# Altium schematic sheet Ribbon Breakout.SchDoc("Ribbon Breakout")
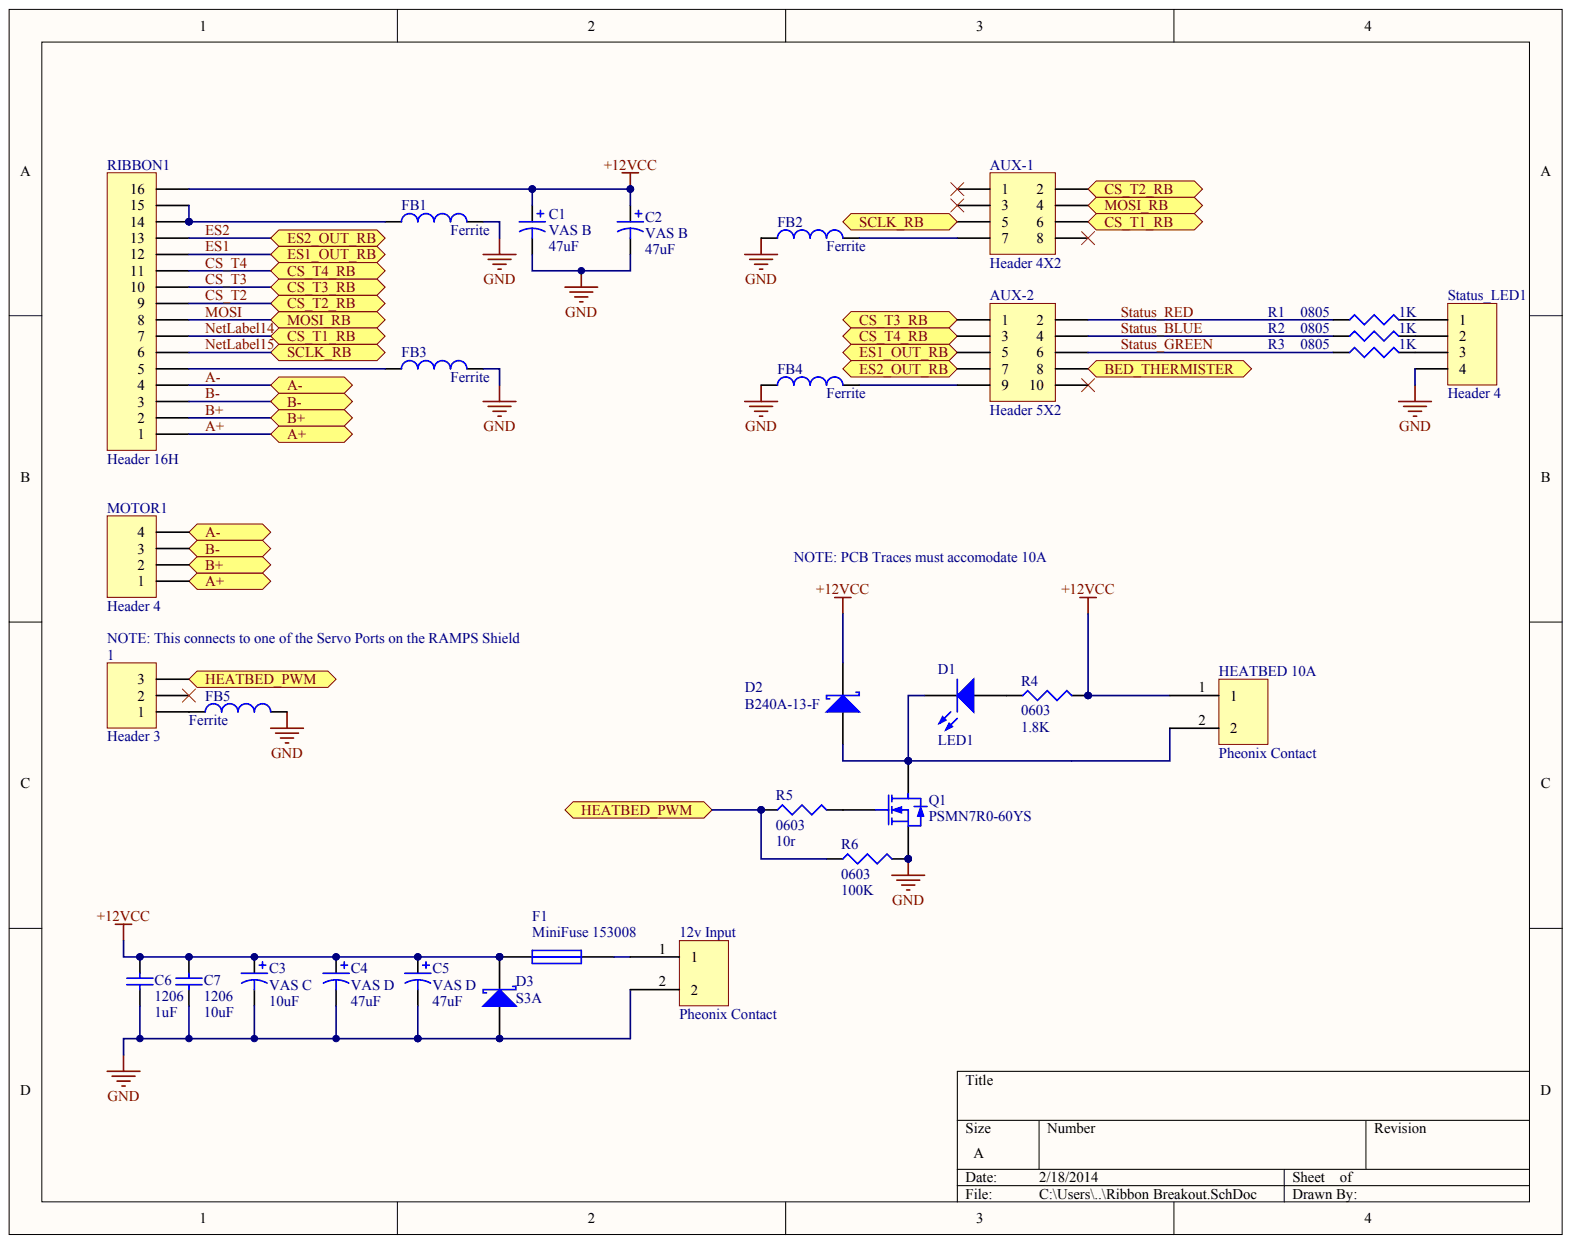
[diagram p0: Ribbon Breakout.SchDoc]

## Parts
1  Header 3
12v_Input  Pheonix Contact
AUX-1  Header 4X2
AUX-2  Header 5X2
C1  VAS B  value 47uF
C2  VAS B  value 47uF
C3  VAS C  value 10uF
C4  VAS D  value 47uF
C5  VAS D  value 47uF
C6  1206  value 1uF
C7  1206  value 10uF
D1  LED1
D2  B240A-13-F
D3  S3A
F1  MiniFuse 153008
FB1  Ferrite
FB2  Ferrite
FB3  Ferrite
FB4  Ferrite
FB5  Ferrite
HEATBED_10A  Pheonix Contact
MOTOR1  Header 4
Q1  PSMN7R0-60YS
R1  0805  value 1K
R2  0805  value 1K
R3  0805  value 1K
R4  0603  value 1.8K
R5  0603  value 10r
R6  0603  value 100K
RIBBON1  Header 16H
Status_LED1  Header 4

## Ports
A+, A-, B+, B-, BED_THERMISTER, CS_T1_RB, CS_T2_RB, CS_T3_RB, CS_T4_RB, ES1_OUT_RB, ES2_OUT_RB, HEATBED_PWM, MOSI_RB, SCLK_RB

## Nets
+12VCC: C1.1 C2.1 C3.1 C4.1 C5.1 C6.2 C7.2 D2.1 D3.2 F1.1 HEATBED_10A.1 R4.2 RIBBON1.16
GND: 12v_Input.2 C1.2 C2.2 C3.2 C4.2 C5.2 C6.1 C7.1 D3.1 FB1.2 FB2.1 FB3.2 FB4.1 FB5.2 Q1.1 Q1.2 Q1.3 R6.2 Status_LED1.4
A+: MOTOR1.1 RIBBON1.1 ; port A+
A-: MOTOR1.4 RIBBON1.4 ; port A-
B+: MOTOR1.2 RIBBON1.2 ; port B+
B-: MOTOR1.3 RIBBON1.3 ; port B-
BED_THERMISTER: AUX-2.8 ; port BED_THERMISTER
CS_T2: AUX-1.2 RIBBON1.9 ; port CS_T2_RB
CS_T3: AUX-2.1 RIBBON1.10 ; port CS_T3_RB
CS_T4: AUX-2.3 RIBBON1.11 ; port CS_T4_RB
ES1: AUX-2.5 RIBBON1.12 ; port ES1_OUT_RB
ES2: AUX-2.7 RIBBON1.13 ; port ES2_OUT_RB
HEATBED_PWM: 1.3 R5.1 R6.1 ; port HEATBED_PWM
MOSI: AUX-1.4 RIBBON1.8 ; port MOSI_RB
NETLABEL14: AUX-1.6 RIBBON1.7 ; port CS_T1_RB
NETLABEL15: AUX-1.5 RIBBON1.6 ; port SCLK_RB
STATUS_BLUE: AUX-2.4 R2.1
STATUS_GREEN: AUX-2.6 R3.1
STATUS_RED: AUX-2.2 R1.1
Net1_1: 1.1 FB5.1
Net12v Input_1: 12v_Input.1 F1.2
NetAUX-1_7: AUX-1.7 FB2.2
NetAUX-2_9: AUX-2.9 FB4.2
NetD1_1: D1.1 D2.2 HEATBED_10A.2 Q1.5
NetD1_2: D1.2 R4.1
NetFB1_1: FB1.1 RIBBON1.14 RIBBON1.15
NetFB3_1: FB3.1 RIBBON1.5
NetQ1_4: Q1.4 R5.2
NetR1_2: R1.2 Status_LED1.1
NetR2_2: R2.2 Status_LED1.2
NetR3_2: R3.2 Status_LED1.3

Not connected: 1 2; AUX-1 1 3 8; AUX-2 10

## Library data of the parts
FB1-FB5 = (no symbol name): Code_IEC: 1005; Published: 8-Jun-2000; LatestRevisionDate: 17-Jul-2002; LatestRevisionNote: Re-released for DXP Platform.; PackageReference: 0402-A; Code_JEITA: 0402; Publisher: Altium Limited
R4 = (no symbol name): Value: 1.8K; Published: 8-Jun-2000; LatestRevisionDate: 29-May-2009; LatestRevisionNote: IPC-7351 Footprint Added.; PackageReference: J1-0603; PackageDescription: Chip Resistor; Publisher: Altium Limited
1 12v_Input AUX-1 AUX-2 C1-C7 D1-D3 F1 HEATBED_10A MOTOR1 Q1 R1-R3 R5 R6 RIBBON1 Status_LED1 = (no symbol name)
  1 AUX-1 AUX-2 MOTOR1 RIBBON1 Status_LED1: LatestRevisionDate: 17-Jul-2002; LatestRevisionNote: Re-released for DXP Platform.
  C1-C5: Published: 8-Jun-2000; LatestRevisionDate: 17-Jul-2002; LatestRevisionNote: Re-released for DXP Platform.; PackageReference: RB7.6-15; PackageDescription: Polarized Capacitor (Radial); 2 Leads
  C6 C7: Published: 8-Jun-2000; LatestRevisionDate: 29-May-2009; LatestRevisionNote: IPC-7351 Footprint Added.; PackageReference: C1206; PackageDescription: Chip Capacitor
  D1: Published: 8-Jun-2000; LatestRevisionDate: 08-Jul-2005; LatestRevisionNote: Mech Layer 1 removed.; PackageReference: LED-1; PackageDescription: LED; 2 Leads
  D2 D3: Published: 8-Jun-2000; LatestRevisionDate: 17-Jul-2002; LatestRevisionNote: Re-released for DXP Platform.; PackageReference: SMB; PackageDescription: DO-214-AA/SMB; 2 C-Bend Leads; Body 5.3 x 3.6 mm, inc. leads (LxW); Code_JEDEC: DO-214-AA
  F1: Published: 8-Jun-2000; LatestRevisionDate: 17-Jul-2002; LatestRevisionNote: Re-released for DXP Platform.; PackageReference: PIN-W2/E2.8; PackageDescription: Thru-Hole; 2 Leads
  Q1: Published: 8-Jun-2000; LatestRevisionDate: 17-Jul-2002; LatestRevisionNote: Re-released for DXP Platform.; PackageVersion: 1995/1996; PackageReference: E3; PackageDescription: TO, Flat Index; 3 In-Line, Axial Leads; Body Dia. 4.6mm; Lead Dia. 0.5 mm (max)
  R1-R3 R5 R6: Published: 8-Jun-2000; LatestRevisionDate: 29-May-2009; LatestRevisionNote: IPC-7351 Footprint Added.; PackageReference: J1-0603; PackageDescription: Chip Resistor
  1 AUX-1 AUX-2 C1-C7 D1-D3 F1 MOTOR1 Q1 R1-R3 R5 R6 RIBBON1 Status_LED1: Publisher: Altium Limited
